# Altium schematic sheet Power Board.SchDoc
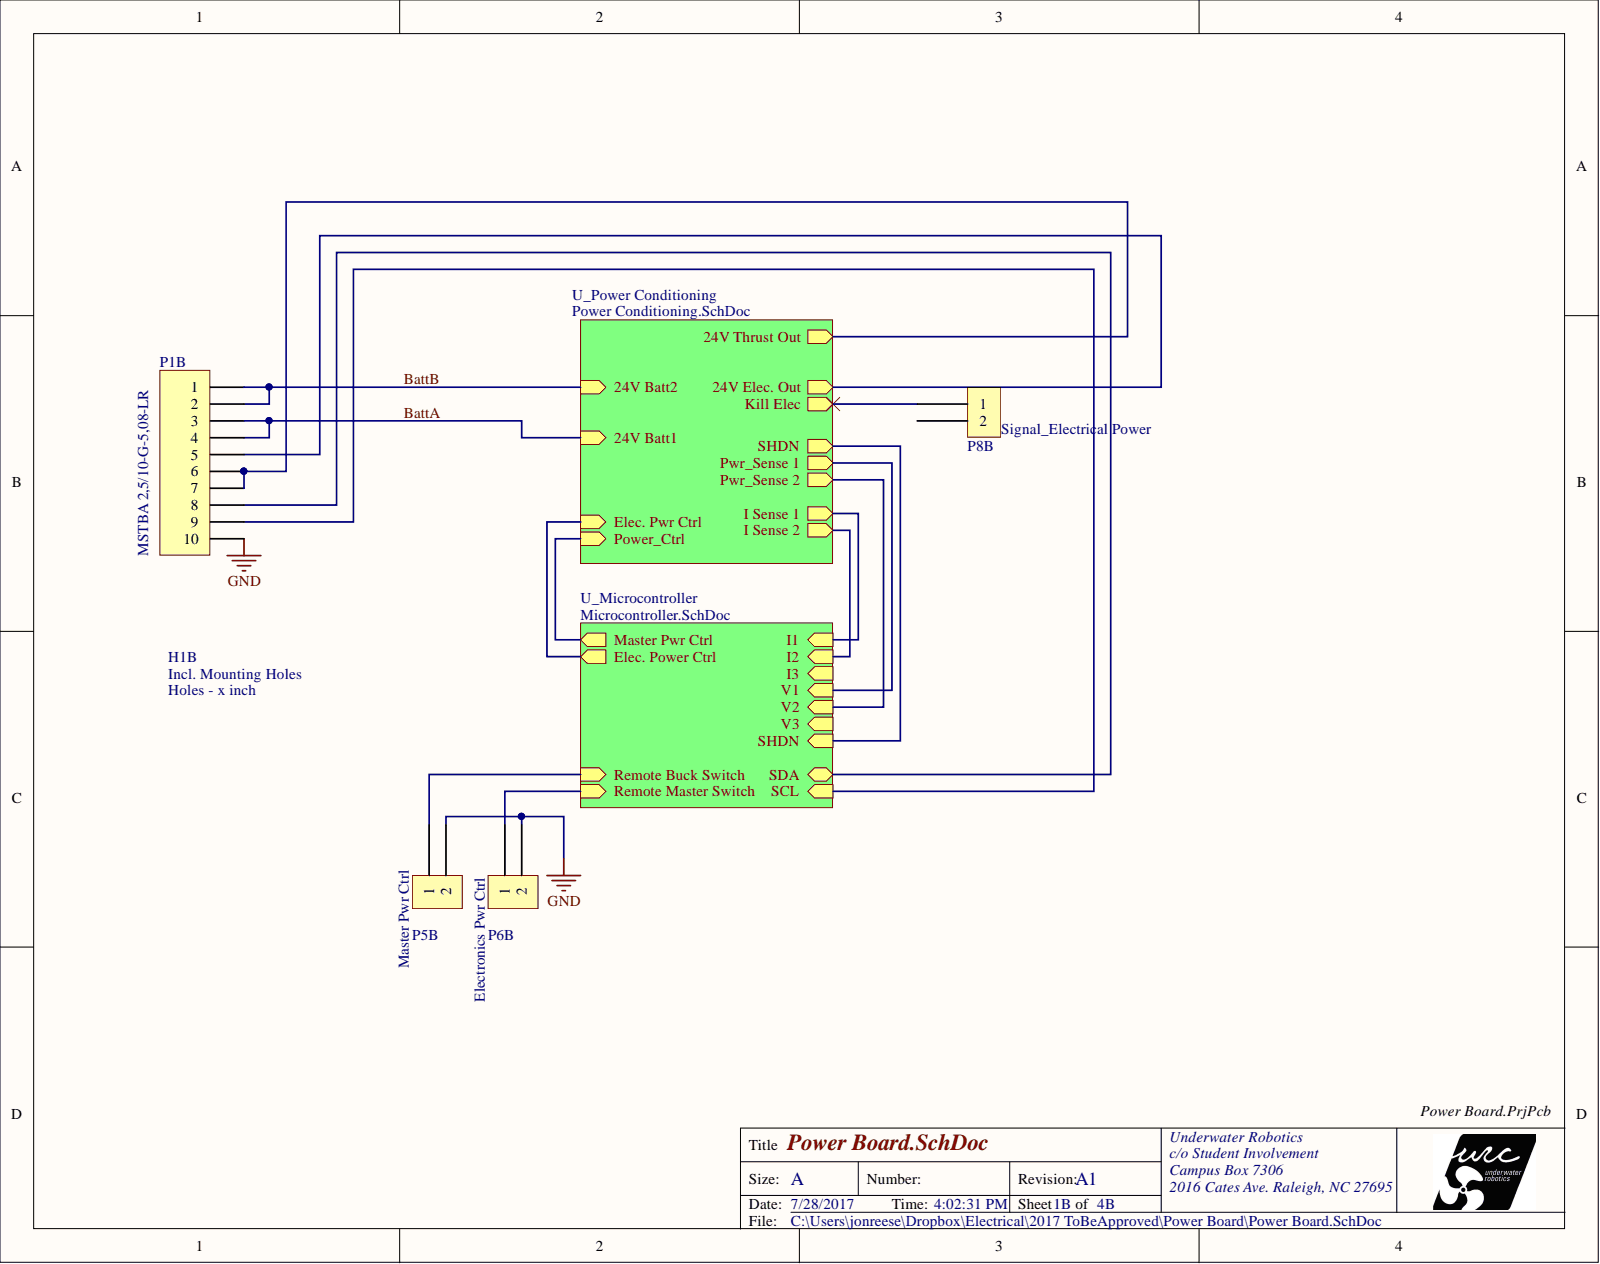
[diagram p0: Power Board.SchDoc]

## Parts
H1B  Holes - x inch  [110mil Holes - 2.5  Inch]
P1B  MSTBA 2,5/10-G-5,08  Manufacturer Part Number: MSTBA 2,5/10-G-5,08-LR  [MSTBA 2,5/10-G-5,08]
P5B  Header  [1x2 Header]  (value not drawn)
P6B  Header  [1x2 Header]  (value not drawn)
P8B  Header  [1x2 Header]  (value not drawn)

## Nets
GND: P1B.10 P5B.2 P6B.2
BattA: P1B.3 P1B.4
BattB: P1B.1 P1B.2
NetP1B_5: P1B.5
NetP1B_6: P1B.6 P1B.7
NetP1B_8: P1B.8
NetP1B_9: P1B.9
NetP5B_1: P5B.1
NetP6B_1: P6B.1
NetP8B_1: P8B.1
NetP8B_2: P8B.2
Elec. Power Ctrl:
I1:
I2:
I3:
Master Pwr Ctrl:
SHDN:
V1:
V2:
V3:

## Library data of the parts
P1B = MSTBA 2,5/10-G-5,08: Header, 10-Pin; Manufacturer 1: PHOENIX CONTACT; Description 1: PHOENIX CONTACT - MSTBA 2,5/10-G-5,08-LR - TERMINAL BLOCK, HEADER, 10POS, TH; Component Kind: Standard; ComponentLink1Description: http://www.farnell.com/datasheets/1702208.pdf; ComponentLink1URL: http://www.farnell.com/datasheets/1702208.pdf; Pricing 1: 1=3.07, 100=2.89, 250=2.67, 500=2.55, 1000=2.27 (USD); RoHS: YES; Signal Integrity: Connector; Stock 1: 37; Supplier 1: Newark; Supplier Part Number 1: 88W5041; URL: http://www.mouser.com/ProductDetail/Phoenix-Contact/1792708/?qs=sGAEpiMZZMsDddcp1dBDJI66tvLKBAoKnRJwuCPjeJk%3d; Library Name: Pheonix Connectors.SchLib
P5B P6B P8B = 1x2 Header: Component Kind: Standard; Description: 1x2 Header; Library Name: URC_Lib.IntLib
H1B = Holes - x inch: Component Kind: Standard; Library Name: URC.SchLib
